# Altium schematic sheet Main.SchDoc(Main)
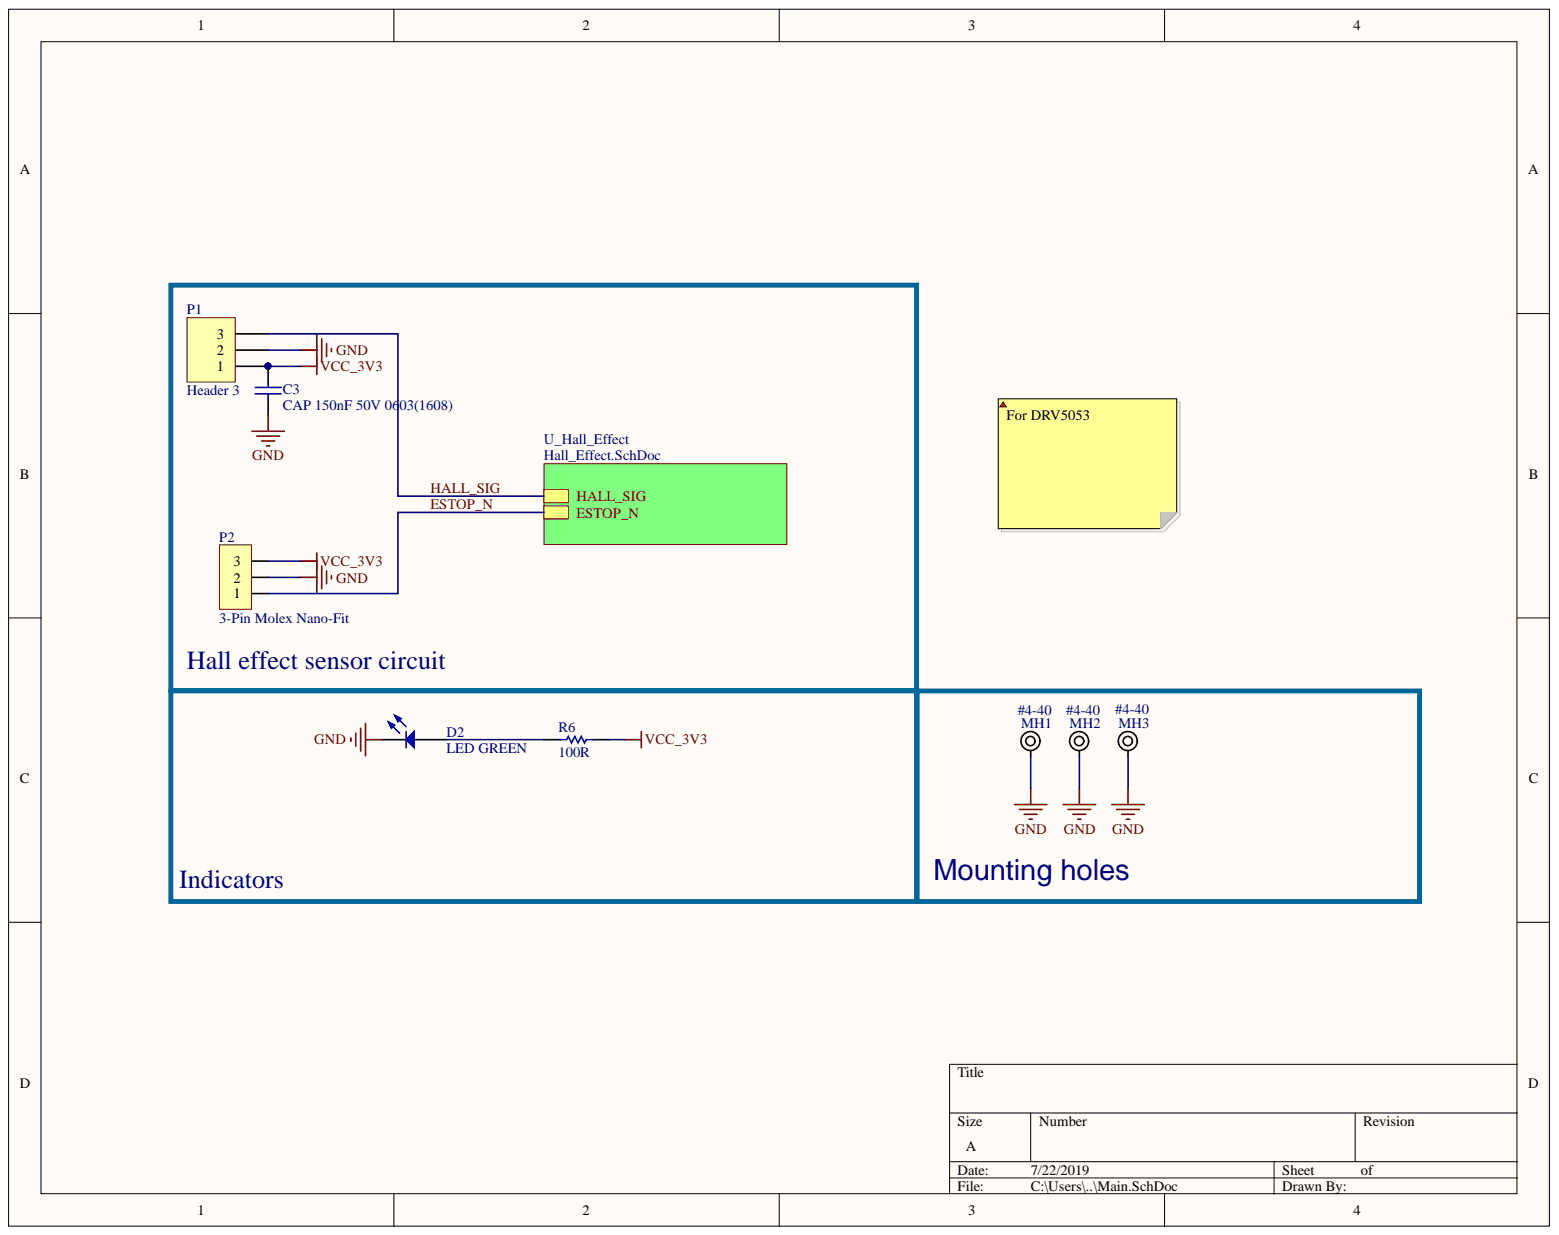
[diagram p0: Main.SchDoc]

## Parts
C3  CAP 150nF 50V 0603(1608)  value 150nF  [CAPC0603(1608)100_M]
D2  LED GREEN  [LED_0805]
MH1  #4-40  [Mounting Hole #4-40]
MH2  #4-40  [Mounting Hole #4-40]
MH3  #4-40  [Mounting Hole #4-40]
P1  Header 3  [HDR1X3]
P2  3-Pin Molex Nano-Fit  Manufacturer: Molex  [MOLEX NANO-FIT 1X3]
R6  100R  [RESC0603(1608)_N]

## Nets
GND: C3.2 D2.1 MH1.0 MH2.0 MH3.0 P1.2 P2.2
VCC_3V3: C3.1 P1.1 P2.3 R6.2
ESTOP_N: P2.1
HALL_SIG: P1.3
NetD2_2: D2.2 R6.1

## Library data of the parts
C3 = CMP-1035-02624-1: CAP 150nF 50V -20% to +80% 0603 (1608 Metric) Thickness 1mm SMD; Case-Metric: 1608; Case-EIA: 0603; Tolerance: -20% to +80%; Technology: SMT; Rated Voltage: 50 V; Max Thickness: 1 mm; Library Name: Altium Content Vault; Component Kind: Standard
D2 = LED0: led; Manufacturer Part Number: LTST-C171KGKT; Manufacturer: Lite-On Inc.; Wavelength - Peak: 574nm; Wavelength - Dominant: 571nm; Voltage - Forward (Vf) (Typ): 2V; Viewing Angle: 130°; Millicandela Rating: 35mcd; Lens Transparency: Clear; Lens Style/Size: Rectangle with Flat Top, 1.40mm x 1.25mm; Lens Color: Colorless; Current - Test: 20mA; Supplier Part Number 1: 160-1426-1-ND; Supplier 1: Digi-Key; Stock 1: 350441; Size / Dimension: 2.00mm L x 1.25mm W; Simulation: LED0; RoHS: RoHS Compliant; Pricing 1: 1=0.31, 10=0.225, 25=0.1656, 100=0.1183, 250=0.0804, 500=0.07096, 1000=0.05558 (USD); Part Status: Active; Packaging: Cut Tape (CT); Package / Case: 0805 (2012 Metric); Mounting Type: Surface Mount; Height (Max): 0.90mm; Features: -; ComponentLink1URL: http://optoelectronics.liteon.com/upload/download/DS22-2000-118/LTST-C171KGKT.pdf; ComponentLink1Description: http://optoelectronics.liteon.com/upload/download/DS22-2000-118/LTST-C171KGKT.pdf; Component Kind: Standard; Color: Green; Category: Optoelectronics; Library Name: CMR_Miscellaneous_Devices.IntLib
MH1-MH3 = Mounting Hole #4-40, Loose Fit: Mounting Hole for ANSI #4-40 w/ Flat Washer, Loose Fit; Datasheet: http://blogs.mentor.com/tom-hausherr/blog/tag/pcb-mounting-holes/; Component Kind: Standard; Library Name: CMR_PCB_Components.IntLib
P1 = Header 3: Header, 3-Pin; Signal Integrity: Connector; Library Name: Miscellaneous Connectors.IntLib; LatestRevisionNote: Re-released for DXP Platform.; LatestRevisionDate: 17-Jul-2002; Component Kind: Standard; Publisher: Altium Limited
P2 = 3-Pin Nano-Fit: NanoFit RA Hdr TH SR 3Ckt; Part Number: 105313-1204; Supplier Part Number: 538-105313-1204; Supplier: Mouser; Component Kind: Standard; Library Name: CMR_Connectors.IntLib
R6 = CMP-1012-00050-1: 100R 0.1W 5% 0603 (1608 Metric)  SMD; Value: 100.00 Ohm; Case-Metric: 1608; Case-EIA: 0603; Tolerance: 5 %; Technology: SMT; Power: 0.1 W; Library Name: Altium Content Vault; Component Kind: Standard
